# Altium schematic sheet [04] - ETHERCAT.SchDoc(ETHERCAT)
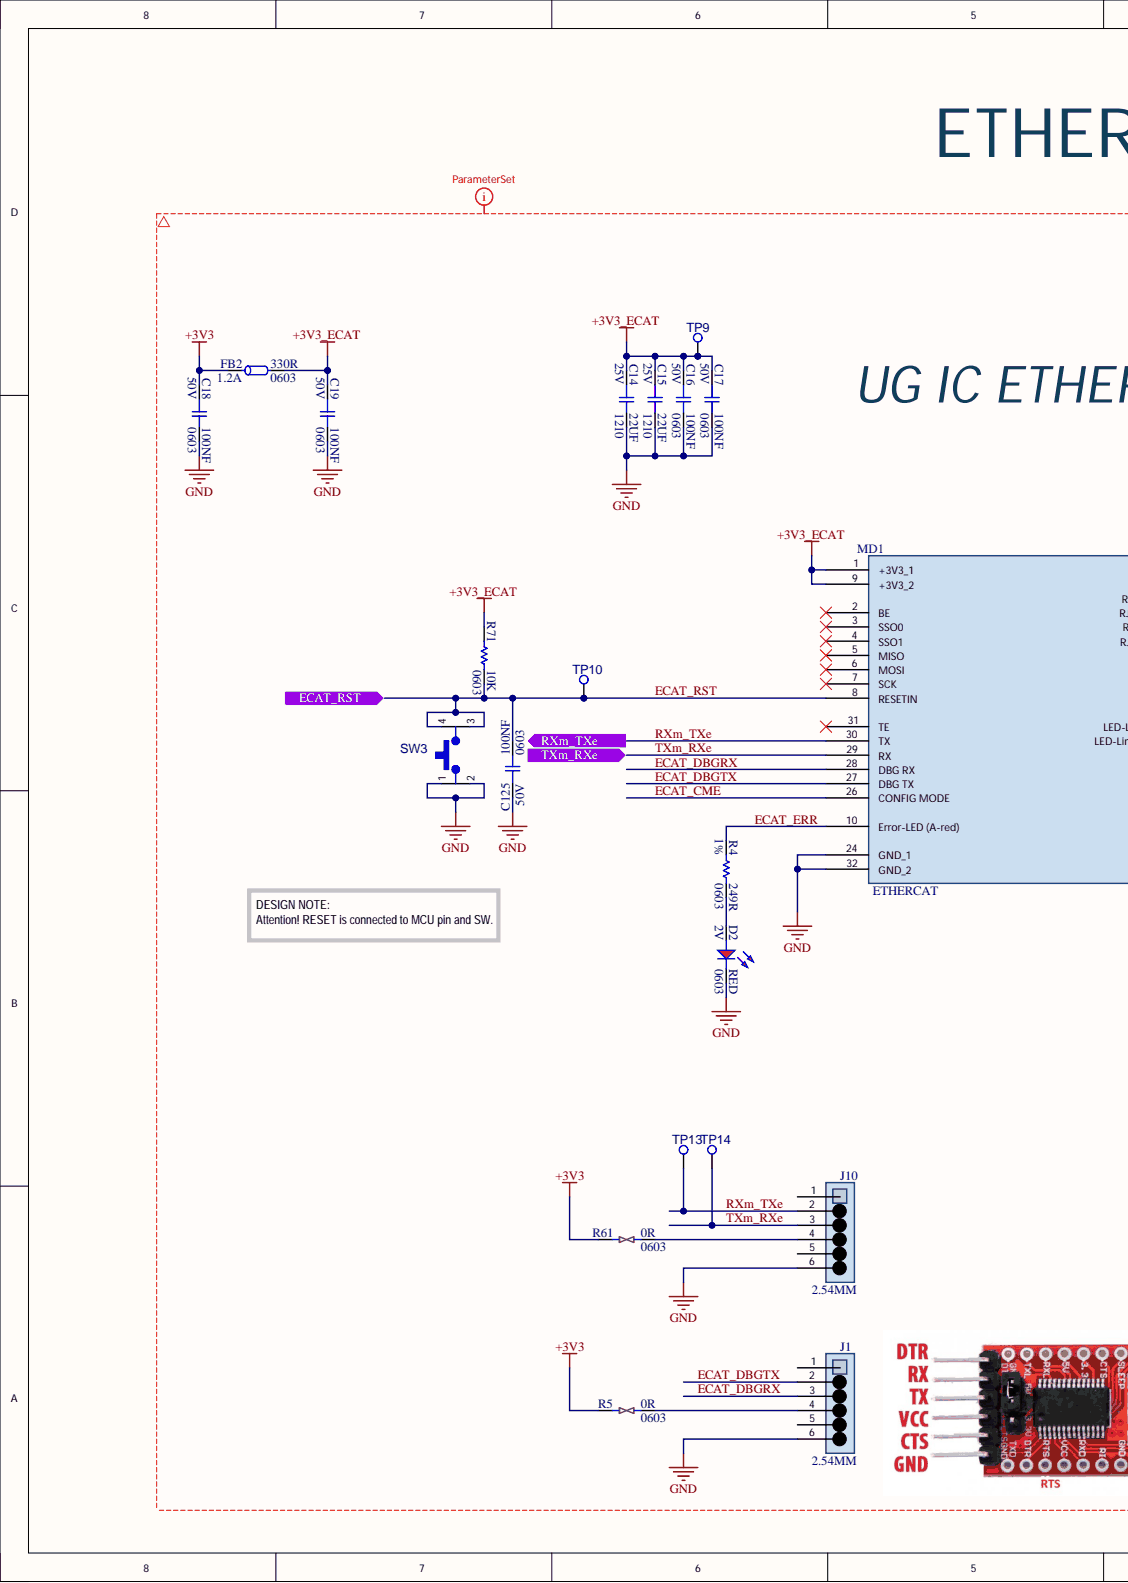
[diagram p0: [04] - ETHERCAT.SchDoc, left]
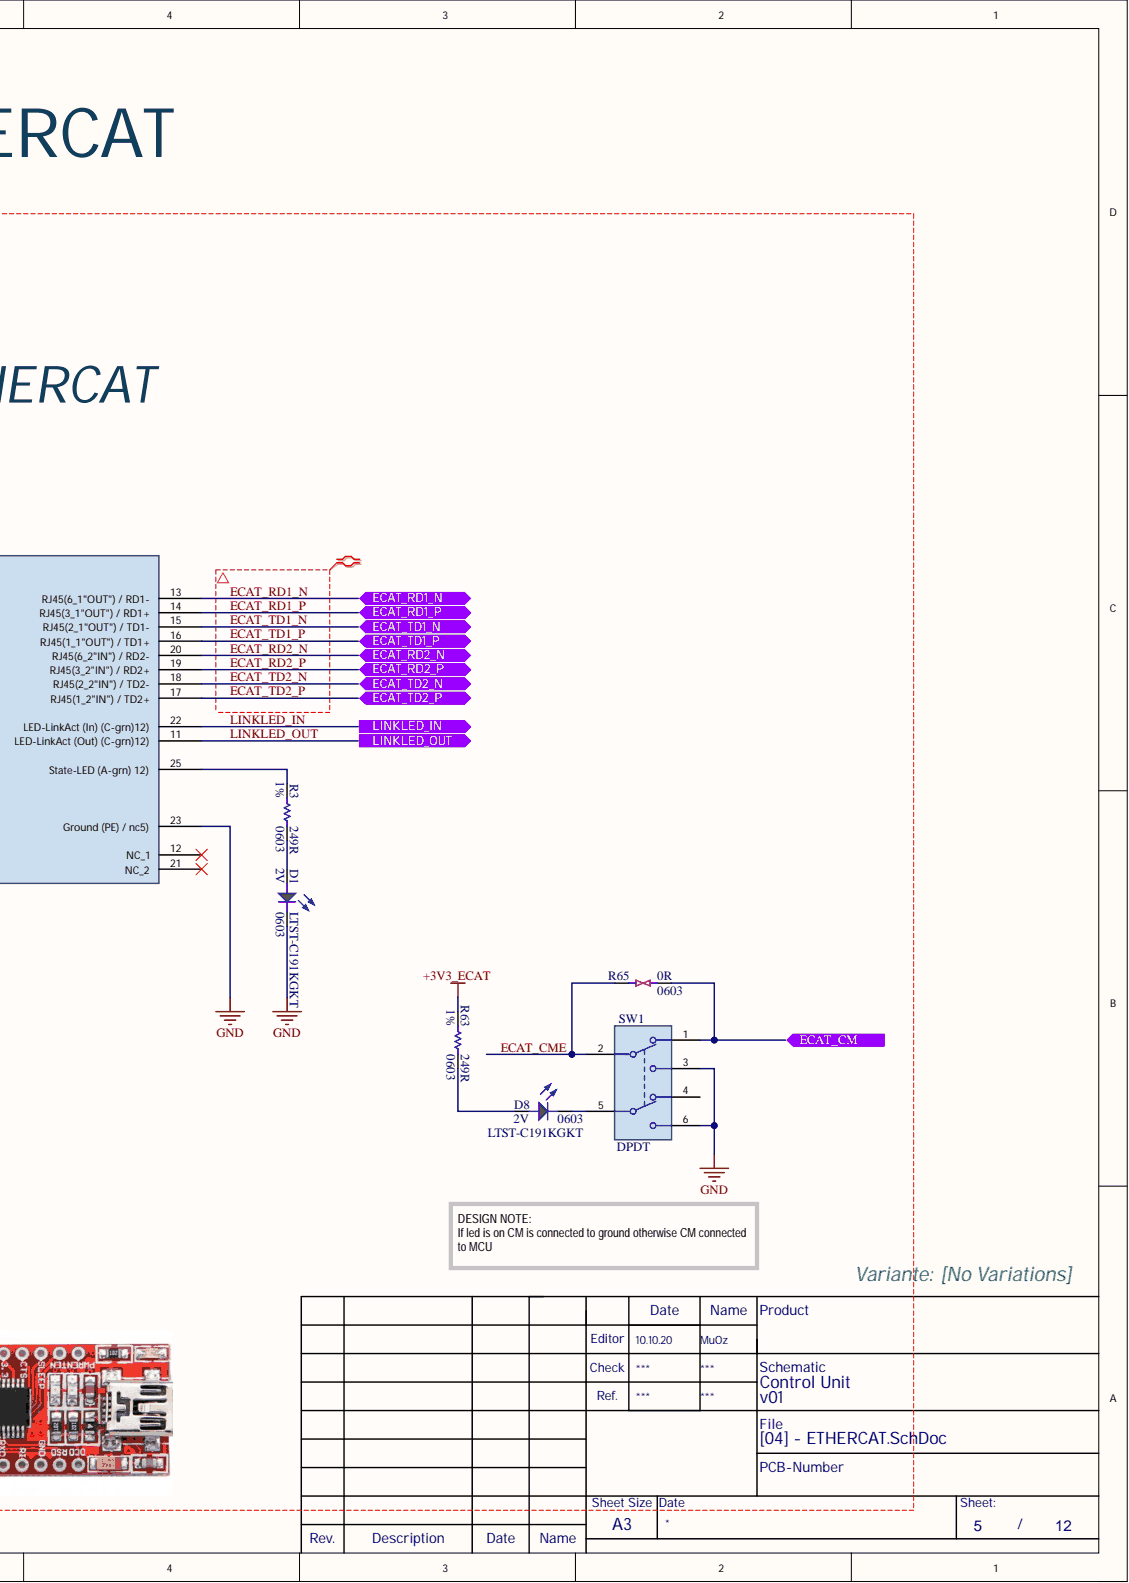
[diagram p1: [04] - ETHERCAT.SchDoc, right]

## Parts
C14  22UF  Case Code (Imperial): 1210  Voltage: 25V  [C-1210]
C15  22UF  Case Code (Imperial): 1210  Voltage: 25V  [C-1210]
C16  100NF  Case Code (Imperial): 0603  Voltage: 50V  [C-0603]
C17  100NF  Case Code (Imperial): 0603  Voltage: 50V  [C-0603]
C18  100NF  Case Code (Imperial): 0603  Voltage: 50V  [C-0603]
C19  100NF  Case Code (Imperial): 0603  Voltage: 50V  [C-0603]
C125  100NF  Case Code (Imperial): 0603  Voltage: 50V  [C-0603]
D1  LTST-C191KGKT  Case Code (Imperial): 0603  Forward Voltage: 2V  [LED-0603-GREEN]
D2  RED  Case Code (Imperial): 0603  Forward Voltage: 2V  [LED-0603]
D8  LTST-C191KGKT  Case Code (Imperial): 0603  Forward Voltage: 2V  [LED-0603-GREEN]
FB2  330R  Case Code (Imperial): 0603  Current Rating: 1.2A  [FB-0603]
J1  2.54MM  [CON-1X6-VERT-2.54MM]
J10  2.54MM  [CON-1X6-VERT-2.54MM]
MD1  ETHERCAT  [MD-UG-IC-PIN]
R3  249R  Case Code (Imperial): 0603  Tolerance: 1%  [R-0603]
R4  249R  Case Code (Imperial): 0603  Tolerance: 1%  [R-0603]
R5  0R  Case Code (Imperial): 0603  [JMP-0603]
R61  0R  Case Code (Imperial): 0603  [JMP-0603]
R63  249R  Case Code (Imperial): 0603  Tolerance: 1%  [R-0603]
R65  0R  Case Code (Imperial): 0603  [JMP-0603]
R71  10K  Case Code (Imperial): 0603  [R-0603]
SW1  DPDT  [SW-AYZ0202AGRLC]
SW3  SW-TACTILE-5MM  [TACTILE_SMD_V2]  (value not drawn)
TP9  MECH-TESTPOINT  [MECH-TESTPOINT]  (value not drawn)  (no BOM)
TP10  MECH-TESTPOINT  [MECH-TESTPOINT]  (value not drawn)  (no BOM)
TP13  MECH-TESTPOINT  [MECH-TESTPOINT]  (value not drawn)  (no BOM)
TP14  MECH-TESTPOINT  [MECH-TESTPOINT]  (value not drawn)  (no BOM)

## Ports
ECAT_CM, ECAT_RD1_N, ECAT_RD1_P, ECAT_RD2_N, ECAT_RD2_P, ECAT_RST, ECAT_TD1_N, ECAT_TD1_P, ECAT_TD2_N, ECAT_TD2_P, LINKLED_IN, LINKLED_OUT, RXm_TXe, TXm_RXe

## Nets
+3V3: C18.1 FB2.1 R5.1 R61.1
+3V3_ECAT: C14.1 C15.1 C16.1 C17.1 C19.1 FB2.2 MD1.1(+3V3_1) MD1.9(+3V3_2) R63.1 R71.1 TP9.1
GND: C14.2 C15.2 C16.2 C17.2 C18.2 C19.2 C125.1 D1.C D2.C J1.6 J10.6 MD1.23(Ground_(PE)_/_nc5)) MD1.24(GND_1) MD1.32(GND_2) SW1.3 SW1.6 SW3.1 SW3.2
ECAT_CM: R65.2 SW1.1 ; port ECAT_CM
ECAT_CME: MD1.26(CONFIG_MODE) R65.1 SW1.2
ECAT_DBGRX: J1.3 MD1.28(DBG_RX)
ECAT_DBGTX: J1.2 MD1.27(DBG_TX)
ECAT_ERR: MD1.10(Error-LED_(A-red)) R4.1
ECAT_RD1_N: MD1.13(RJ45(6_1"OUT")_/_RD1-) ; port ECAT_RD1_N
ECAT_RD1_P: MD1.14(RJ45(3_1"OUT")_/_RD1+) ; port ECAT_RD1_P
ECAT_RD2_N: MD1.20(RJ45(6_2"IN")_/_RD2-) ; port ECAT_RD2_N
ECAT_RD2_P: MD1.19(RJ45(3_2"IN")_/_RD2+) ; port ECAT_RD2_P
ECAT_RST: C125.2 MD1.8(RESETIN) R71.2 SW3.3 SW3.4 TP10.1 ; port ECAT_RST
ECAT_TD1_N: MD1.15(RJ45(2_1"OUT")_/_TD1-) ; port ECAT_TD1_N
ECAT_TD1_P: MD1.16(RJ45(1_1"OUT")_/_TD1+) ; port ECAT_TD1_P
ECAT_TD2_N: MD1.18(RJ45(2_2"IN")_/_TD2-) ; port ECAT_TD2_N
ECAT_TD2_P: MD1.17(RJ45(1_2"IN")_/_TD2+) ; port ECAT_TD2_P
LINKLED_IN: MD1.22(LED-LinkAct_(In)_(C-grn)12)) ; port LINKLED_IN
LINKLED_OUT: MD1.11(LED-LinkAct_(Out)_(C-grn)12)) ; port LINKLED_OUT
RXm_TXe: J10.2 MD1.30(TX) TP13.1 ; port RXm_TXe
TXm_RXe: J10.3 MD1.29(RX) TP14.1 ; port TXm_RXe
NetD1_A: D1.A R3.2
NetD2_A: D2.A R4.2
NetD8_A: D8.A R63.2
NetD8_C: D8.C SW1.5
NetJ1_4: J1.4 R5.2
NetJ10_4: J10.4 R61.2
NetMD1_25: MD1.25(State-LED_(A-grn)_12)) R3.1

Not connected: J1 1 5; J10 1 5; MD1 2(BE) 3(SSO0) 4(SSO1) 5(MISO) 6(MOSI) 7(SCK) 12(NC_1) 21(NC_2) 31(TE); SW1 4

## Library data of the parts
C14 C15 = C-22UF-1210: CAP CER 22UF 25V X7R 1210; Manufacturer: Samsung; Manufacturer Part Number: CL32B226KAJNNNE; .Creator: F:TALI; .PCBCHK: CHECKED; Dielectric Material: Ceramic; Dissipation Factor: 10%; Washability: OK; .SCHCHK: CHECKED; Capacitance: 22uF; Case Code (Metric): 3225; Case/Package: 1210; Component Kind: Standard; ComponentLink1Description: https://media.digikey.com/pdf/Data%20Sheets/Samsung%20PDFs/CL_Series_MLCC_ds.pdf; ComponentLink1URL: https://media.digikey.com/pdf/Data%20Sheets/Samsung%20PDFs/CL_Series_MLCC_ds.pdf; ComponentLink2Description: http://www.samsungsem.com/kr/support/product-search/mlcc/CL32B226KAJNFNE.jsp; ComponentLink2URL: http://www.samsungsem.com/kr/support/product-search/mlcc/CL32B226KAJNFNE.jsp; Depth: 2.5mm; Dielectric: X7R; Height: 2.5mm; Lead Free: Lead Free; Length: 3.2mm; Material: Ceramic; Max Operating Temperature: 125°C; Min Operating Temperature: -55°C; Mount: Surface Mount; Package Quantity: 1; Packaging: Tape and Reel; Pricing 1: 1=1.02, 10=0.777, 100=0.556, 500=0.43042 (USD); Pricing 2: 1=0.91, 10=0.671, 100=0.4598, 500=0.36402 (USD); Pricing 3: 1=1.63, 10=1.289, 100=0.9664, 500=0.7302 (USD); Radiation Hardening: No; Stock 1: 0; Stock 2: 0; Stock 3: 0; Supplier 1: Digi-Key; Supplier 2: Digi-Key; Supplier 3: Digi-Key; Supplier Part Number 1: 1276-3392-1-ND; Supplier Part Number 2: 1276-3391-6-ND; Supplier Part Number 3: 399-11958-6-ND; Termination: SMD/SMT; Thickness: 0.106inch; Tolerance: 10%; Voltage Rating: 25V; Voltage Rating (DC): 25V; Width: 0.098inch; Library Name: BARKO_SCHLIB.SchLib
C16-C19 C125 = C-100NF-0603: CAP CER 0.1UF 50V X7R 0603; Manufacturer: TDK; Manufacturer Part Number: C1608X7R1H104K080AA; .Creator: F:TALI; .PCBCHK: USED; Dielectric Material: Ceramic; Temperature Coefficient: 30ppm/°C; Tolerance: 10%; Washability: OK; .SCHCHK: USED; Capacitance: 100nF; Case Code (Metric): 1608; Case/Package: 0603; Component Kind: Standard; ComponentLink1Description: http://www.mouser.com/ds/2/400/lcc_commercial_general_en-837201.pdf; ComponentLink1URL: http://www.mouser.com/ds/2/400/lcc_commercial_general_en-837201.pdf; ComponentLink2Description: https://product.tdk.com/info/en/catalog/spec/mlccspec_commercial_general_midvoltage_en.pdf; ComponentLink2URL: https://product.tdk.com/info/en/catalog/spec/mlccspec_commercial_general_midvoltage_en.pdf; ComponentLink3Description: http://uk.rs-online.com/webdocs/13d1/0900766b813d1103.pdf; ComponentLink3URL: http://uk.rs-online.com/webdocs/13d1/0900766b813d1103.pdf; ComponentLink4Description: http://www.tdk.co.jp/tefe02/e412_c.pdf; ComponentLink4URL: http://www.tdk.co.jp/tefe02/e412_c.pdf; ComponentLink5Description: http://www.farnell.com/datasheets/2291921.pdf; ComponentLink5URL: http://www.farnell.com/datasheets/2291921.pdf; ComponentLink6Description: http://www.farnell.com/datasheets/1744655.pdf; ComponentLink6URL: http://www.farnell.com/datasheets/1744655.pdf; ComponentLink7Description: http://catalogs.avx.com/SurfaceMount.pdf; ComponentLink7URL: http://catalogs.avx.com/SurfaceMount.pdf; ComponentLink8Description: http://www.farnell.com/datasheets/630895.pdf; ComponentLink8URL: http://www.farnell.com/datasheets/630895.pdf; ComponentLink9Description: http://www.avx.com/docs/Catalogs/cx7r.pdf; ComponentLink9URL: http://www.avx.com/docs/Catalogs/cx7r.pdf; ComponentLink10Description: http://www.avx.com/docs/catalogs/cauto.pdf; ComponentLink10URL: http://www.avx.com/docs/catalogs/cauto.pdf; ComponentLink11Description: https://www.verical.com/server-webapp/html/getDatasheet?partID=98691; ComponentLink11URL: https://www.verical.com/server-webapp/html/getDatasheet?partID=98691; ComponentLink12Description: https://www.avx.com/docs/Catalogs/flexiterm.pdf; ComponentLink12URL: https://www.avx.com/docs/Catalogs/flexiterm.pdf; Composition: Ceramic; Contact Plating: Matte,Tin; Depth: 0.8mm; Dielectric: X7R; Features: Soft Termination; Height: 0.8mm; Lead Free: Lead Free; Length: 1.6mm; Max Operating Temperature: 125°C; Min Operating Temperature: -55°C; Mount: Surface Mount; Number of Pins: 2; Package Quantity: 1; Packaging: Tape and Reel; Pricing 1: 1=0.1, 10=0.057, 100=0.0254, 500=0.01812, 1000=0.01423 (USD); Pricing 2: 1=0.23, 10=0.161, 100=0.0756, 500=0.05304, 1000=0.0439 (USD); Radiation Hardening: No; REACH SVHC: No SVHC; Stock 1: 0; Stock 2: 40000; Supplier 1: Digi-Key; Supplier 2: Digi-Key; Supplier Part Number 1: 445-1314-1-ND; Supplier Part Number 2: 478-3540-6-ND; Termination: SMD/SMT; Thickness: 0.037inch; Voltage Rating: 50V; Voltage Rating (DC): 50V; Weight: 7.1E-05oz; Width: 0.8mm; Library Name: BARKO_SCHLIB.SchLib
D1 D8 = LED-GREEN-0603: LED GREEN CLEAR 0603 SMD; Manufacturer: Vishay Lite-On; .Creator: F:TALI; .PCBCHK: USED; Dominant Wavelength: 571nm; Forward Current: 30mA; Lens Colour: Clear,Colorless; Lens Style: Clear,Colorless,Diffused,Rectangular; Luminous Intensity: 35mcd; Number of Elements: 1; Power Dissipation: 75mW; Reverse Voltage: 5V; Test Current: 20mA; Viewing Angle: 130°; Washability: OK; Wavelength: 574nm; .SCHCHK: USED; Case Code (Metric): 1608; Case/Package: 0603; Colour: Green; Component Kind: Standard; ComponentLink1Description: http://www.mouser.com/ds/2/239/LTST-C191KGKT-1141954.pdf; ComponentLink1URL: http://www.mouser.com/ds/2/239/LTST-C191KGKT-1141954.pdf; ComponentLink2Description: http://optoelectronics.liteon.com/upload/download/DS22-2000-228/LTST-C191KGKT.PDF; ComponentLink2URL: http://optoelectronics.liteon.com/upload/download/DS22-2000-228/LTST-C191KGKT.PDF; ComponentLink3Description: https://www.verical.com/server-webapp/html/getDatasheet?partID=103913; ComponentLink3URL: https://www.verical.com/server-webapp/html/getDatasheet?partID=103913; ComponentLink4Description: http://uk.rs-online.com/webdocs/0dc0/0900766b80dc02b1.pdf; ComponentLink4URL: http://uk.rs-online.com/webdocs/0dc0/0900766b80dc02b1.pdf; ComponentLink5Description: http://www1.futureelectronics.com/doc/LITE-ON/LTST-C191KGKT.pdf; ComponentLink5URL: http://www1.futureelectronics.com/doc/LITE-ON/LTST-C191KGKT.pdf; Depth: 0.8mm; Height: 0.55mm; Illumination Colour: Green; Lead Free: Lead Free; Length: 1.6mm; Lens Transparency: Clear; Manufacturer Part Number: LTST-C191KGKT; Max Operating Temperature: 85°C; Min Operating Temperature: -55°C; Mount: Surface Mount; Number of LEDs: 1; Number of Pins: 2; Package Quantity: 1; Packaging: Tape and Reel; Power Rating: 75mW; Pricing 1: 1=0.29, 10=0.215, 25=0.16, 100=0.1153, 250=0.0798, 500=0.07088, 1000=0.05644, 2500=0.05533 (USD); Radiation Hardening: No; Stock 1: 2123523; Supplier 1: Digi-Key; Supplier Part Number 1: 160-1446-1-ND; Width: 0.8mm; Library Name: BARKO_SCHLIB.SchLib
D2 = LED-RED-0603: LED RED CLEAR 0603 SMD; Manufacturer: Wurth Electronics; Manufacturer Part Number: 150060RS75000; Dominant Wavelength: 625nm; Forward Current: 30mA; Lens Style: Clear,Rectangular; Luminous Intensity: 250mcd; Test Current: 20mA; Viewing Angle: 140°; Case Code (Metric): 1608; Case/Package: 0603; Color: Red; Component Kind: Standard; ComponentLink1Description: https://datasheet.ciiva.com/29563/150060rs75000-29563040.pdf?src-supplier=Digi-Key; ComponentLink1URL: https://datasheet.ciiva.com/29563/150060rs75000-29563040.pdf?src-supplier=Digi-Key; ComponentLink2Description: https://datasheet.ciiva.com/29279/759428-da-01-en-chipled-0603-w-kl-rot-150060rs75000-29279196.pdf?src-supplier=Conrad; ComponentLink2URL: https://datasheet.ciiva.com/29279/759428-da-01-en-chipled-0603-w-kl-rot-150060rs75000-29279196.pdf?src-supplier=Conrad; Height: 0.7mm; Length: 1.6mm; Max Operating Temperature: 85°C; Min Operating Temperature: -40°C; Mount: Surface Mount; Package Quantity: 1; Packaging: Cut Tape; Pricing: 1=0.14, 50=0.133, 100=0.114, 500=0.099 (USD); REACH SVHC: Unknown; RoHS: Yes; Stock: 110086; Supplier 1: Digi-Key; Supplier Part Number 1: 732-4978-1-ND; Wavelength: 625nm; Width: 0.8mm; Library Name: BARKO_SCHLIB.SchLib
FB2 = FB-330R-0603: FERRITE BEAD 330 OHM 0603 1LN; Manufacturer: Murata; Manufacturer Part Number: BLM18PG331SN1D; .Creator: M.OZKAN; .PCBCHK: USED; DC Resistance (DCR): 150mR; Material: Steel,Ferrite; Number of Circuits: 1; Shielding: Unshielded; Test Frequency: 100MHz; Tolerance: 25%; Washability: OK; .SCHCHK: USED; Case Code (Metric): 1608; Case/Package: 0603; Component Kind: Standard; ComponentLink1Description: https://datasheet.ciiva.com/6211/enfa0003-6211710.pdf?src-supplier=Digi-Key; ComponentLink1URL: https://datasheet.ciiva.com/6211/enfa0003-6211710.pdf?src-supplier=Digi-Key; Core Material: Ferrite; DC Current: 1.2A; Depth: 0.95mm; Height: 0.8mm; Impedance: 330R; Lead Free: Lead Free; Length: 1.75mm; Max Current Rating: 1.2A; Max DC Current: 1.2A; Max Operating Temperature: 125°C; Min Operating Temperature: -55°C; Number of Lines: 1; Number of Pins: 2; Package Quantity: 1; Packaging: Tape and Reel; Pricing: 1=0.1, 10=0.076, 25=0.0684, 50=0.05, 100=0.0433, 250=0.0342, 500=0.0288, 1000=0.0243 (USD); Radiation Hardening: No; REACH SVHC: No SVHC; RoHS: Yes; Stock: 106218; Supplier 1: Digi-Key; Supplier Part Number 1: 490-5222-1-ND; Termination: SMD/SMT; Width: 0.8mm; Library Name: BARKO_SCHLIB.SchLib
J1 J10 = CON-6POS-2.54MM: CONN RCPT 6POS 0.1 TIN PCB; Manufacturer 1: Harwin; Manufacturer Part Number: M20-7820646; Connector Type: Receptacle,Socket; Contact Resistance: 20mR; Gender: Female; Max Current Rating: 3A; Number of Contacts: 6; Case/Package: SIL; Category: Headers and Wire Housings; Color: Black; Component Kind: Standard; ComponentLink1Description: http://components.arrow.com/part/dataview/0323_00725884?src-supplier=Arrow+Europe; ComponentLink1URL: http://components.arrow.com/part/dataview/0323_00725884?src-supplier=Arrow+Europe; ComponentLink2Description: http://www.harwin.com/include/downloads/pdfs/2010PAGE120.PDF?src-supplier=Avnet; ComponentLink2URL: http://www.harwin.com/include/downloads/pdfs/2010PAGE120.PDF?src-supplier=Avnet; ComponentLink3Description: https://cdn.harwin.com/pdfs/M20-782.pdf?src-supplier=Digi-Key; ComponentLink3URL: https://cdn.harwin.com/pdfs/M20-782.pdf?src-supplier=Digi-Key; ComponentLink4Description: https://cdn.harwin.com/pdfs/Harwin_PC_Connectors_M20.pdf?src-supplier=Avnet+Europe; ComponentLink4URL: https://cdn.harwin.com/pdfs/Harwin_PC_Connectors_M20.pdf?src-supplier=Avnet+Europe; ComponentLink5Description: https://cdn.harwin.com/pdfs/Harwin_PC_Connectors_M20.pdf?src-supplier=RSComponents; ComponentLink5URL: https://cdn.harwin.com/pdfs/Harwin_PC_Connectors_M20.pdf?src-supplier=RSComponents; ComponentLink6Description: https://cdn.harwin.com/pdfs/Harwin_PC_Connectors_M20.pdf?src-supplier=Mouser; ComponentLink6URL: https://cdn.harwin.com/pdfs/Harwin_PC_Connectors_M20.pdf?src-supplier=Mouser; ComponentLink7Description: https://cdn.harwin.com/pdfs/Harwin_PC_Connectors_M20.pdf?src-supplier=TTI; ComponentLink7URL: https://cdn.harwin.com/pdfs/Harwin_PC_Connectors_M20.pdf?src-supplier=TTI; Contact Gender: Female; Contact Material: Bronze; Contact Plating: Tin; Depth: 2.5mm; Housing Color: Black; Housing Material: Nylon; Length: 15.64mm; Library Name: SCHLIB.SchLib; Max Operating Temperature: 105°C; Min Operating Temperature: -40°C; Mount: Through Hole; Number of Positions: 6; Number of Rows: 1; Orientation: Straight; Package Quantity: 38; Packaging: Rail/Tube; Pitch: 2.54mm; Pricing: 1=0,96000, 10=0,84200, 100=0,72600, 500=0,59400, 1000=0,52800, 5000=0,46200, 10000=0,43890 (USD); Radiation Hardening: No; RoHS: Yes; Stock: 1847; Supplier 1: Digi-Key; Supplier Part Number 1: 952-1809-ND; Termination: Solder
MD1 = MD-UG IC ETHERCAT: UNIGATE® IC - EtherCAT®; Component Kind: Standard; ComponentLink1Description: Datasheet; ComponentLink1URL: https://www.deutschmann.de/en/products/embedded-solutions/unigate-ic/unigate-ic-profinet/?pdf=1&id=0&bs2=0; ComponentLink2Description: Manuel; ComponentLink2URL: https://www.deutschmann.de/downloads//Marketing/Anleitung-Instructions/Handbuecher-Manuals/Gateway/English/UNIGATE%20IC/IC%20PROFINET/IC_PN2Port/IC_PN2Port_e.pdf; Library Name: SCHLIB.SchLib
R3 R4 R63 = R-249R-0603: RES 249 OHM 1% 1/10W 0603; Manufacturer: Stackpole Electronics; Manufacturer Part Number: RMCF0603FT249R; Max Power Dissipation: 100mW; Temperature Coefficient: 100ppm/°C; Case Code (Metric): 1608; Case/Package: 0603; Component Kind: Standard; ComponentLink1Description: http://components.arrow.com/part/dataview/50577616S9712727N1174?src-supplier=Arrow+Electronics; ComponentLink1URL: http://components.arrow.com/part/dataview/50577616S9712727N1174?src-supplier=Arrow+Electronics; ComponentLink2Description: https://www.seielect.com/catalog/SEI-RMCF_RMCP.pdf?src-supplier=Avnet; ComponentLink2URL: https://www.seielect.com/catalog/SEI-RMCF_RMCP.pdf?src-supplier=Avnet; ComponentLink3Description: https://www.seielect.com/Catalog/SEI-RMCF_RMCP.pdf?src-supplier=Digi-Key; ComponentLink3URL: https://www.seielect.com/Catalog/SEI-RMCF_RMCP.pdf?src-supplier=Digi-Key; ComponentLink4Description: https://www.seielect.com/catalog/SEI-Packaging.pdf?src-supplier=Digi-Key; ComponentLink4URL: https://www.seielect.com/catalog/SEI-Packaging.pdf?src-supplier=Digi-Key; ComponentLink5Description: http://www.seielect.com/Catalog/SEI-RMC.pdf?src-supplier=Future+Electronics; ComponentLink5URL: http://www.seielect.com/Catalog/SEI-RMC.pdf?src-supplier=Future+Electronics; Composition: Thick Film; Contact Plating: Tin,Matte; Features: Automotive AEC-Q200; Height: 0.022inch; Lead Free: Lead Free; Length: 0.061inch; Max Operating Temperature: 155°C; Min Operating Temperature: -55°C; Mount: Surface Mount; Number of Terminations: 2; Packaging: Tape and Reel; Power Rating: 100mW; Pricing: 1=0.1, 10=0.015, 100=0.0063, 1000=0.0028, 2500=0.0024 (USD); Radiation Hardening: No; Resistance: 249R; RoHS: Yes; Stock: 137849; Supplier 1: Digi-Key; Supplier Part Number 1: RMCF0603FT249RCT-ND; Width: 0.031inch; Library Name: BARKO_SCHLIB.SchLib
R5 R61 R65 = R-0R-0603: RES SMD 0 OHM 1/10W JUMP 0603; Manufacturer: Yageo; Manufacturer Part Number: RC0603JR-070RL; .Creator: F:TALI; .PCBCHK: USED; Current Rating: 1A; Max Power Dissipation: 100mW; Resistance: 0mR; Temperature Coefficient: 200ppm/°C; Tolerance: 1%; Voltage Rating: 50V; Washability: OK; Working Voltage: 75V; .SCHCHK: USED; Case Code (Metric): 1608; Case/Package: 0603; Component Kind: Standard; ComponentLink1Description: http://www.farnell.com/datasheets/1738695.pdf; ComponentLink1URL: http://www.farnell.com/datasheets/1738695.pdf; ComponentLink2Description: https://www.verical.com/server-webapp/html/getDatasheet?partID=329462; ComponentLink2URL: https://www.verical.com/server-webapp/html/getDatasheet?partID=329462; ComponentLink3Description: http://www.yageo.com/documents/recent/PYu-RC_Group_51_RoHS_L_9.pdf; ComponentLink3URL: http://www.yageo.com/documents/recent/PYu-RC_Group_51_RoHS_L_9.pdf; ComponentLink4Description: http://www.farnell.com/datasheets/443971.pdf; ComponentLink4URL: http://www.farnell.com/datasheets/443971.pdf; ComponentLink5Description: http://documents.rs-components.com/techlib://itc_doclist_GB.htm?stockno=6515918; ComponentLink5URL: http://documents.rs-components.com/techlib://itc_doclist_GB.htm?stockno=6515918; ComponentLink6Description: http://www.farnell.com/datasheets/1868787.pdf; ComponentLink6URL: http://www.farnell.com/datasheets/1868787.pdf; ComponentLink7Description: http://documents.rs-components.com/techlib://itc_doclist_GB.htm?stockno=5048827; ComponentLink7URL: http://documents.rs-components.com/techlib://itc_doclist_GB.htm?stockno=5048827; ComponentLink8Description: http://industrial.panasonic.com/www-data/pdf/AOA0000/AOA0000CE1.pdf; ComponentLink8URL: http://industrial.panasonic.com/www-data/pdf/AOA0000/AOA0000CE1.pdf; ComponentLink9Description: http://www.farnell.com/datasheets/2059623.pdf; ComponentLink9URL: http://www.farnell.com/datasheets/2059623.pdf; ComponentLink10Description: http://www.jameco.com/Jameco/Products/ProdDS/1935748.pdf; ComponentLink10URL: http://www.jameco.com/Jameco/Products/ProdDS/1935748.pdf; ComponentLink11Description: http://www.farnell.com/datasheets/1678796.pdf; ComponentLink11URL: http://www.farnell.com/datasheets/1678796.pdf; ComponentLink12Description: http://www.alliedelec.com/m/d/51cd0790071e1666bdb9d00c57fcf960.pdf; ComponentLink12URL: http://www.alliedelec.com/m/d/51cd0790071e1666bdb9d00c57fcf960.pdf; Composition: Thick Film; Contact Plating: Matte,Tin; Depth: 0.8mm; Features: Moisture Resistant; Height: 0.45mm; Lead Free: Lead Free; Length: 1.6mm; Max Operating Temperature: 155°C; Military Standard: Not; Min Operating Temperature: -55°C; Mount: Surface Mount; Number of Pins: 2; Number of Terminations: 2; Package Quantity: 5000; Packaging: Tape and Reel; Power Rating: 100mW; Pricing 1: 1=0.1, 10=0.021, 25=0.0152, 50=0.0114, 100=0.0084, 250=0.00644, 500=0.00514, 1000=0.00379 (USD); Pricing 2: 1=0.1, 10=0.021, 25=0.0152, 50=0.0114, 100=0.0084, 250=0.00644, 500=0.00514, 1000=0.00379 (USD); Pricing 3: 1=0.1, 10=0.042, 25=0.0304, 50=0.0232, 100=0.0171, 250=0.01308, 500=0.01046, 1000=0.0077 (USD); Radiation Hardening: No; REACH SVHC: No SVHC; Stock 1: 54397731; Stock 2: 6104331; Stock 3: 34178498; Supplier 1: Digi-Key; Supplier 2: Digi-Key; Supplier 3: Digi-Key; Supplier Part Number 1: 311-0.0GRDKR-ND; Supplier Part Number 2: 311-0.0HRDKR-ND; Supplier Part Number 3: P0.0GDKR-ND; Termination: SMD/SMT; Voltage Rating (DC): 50V; Width: 0.8mm; Library Name: BARKO_SCHLIB.SchLib
R71 = R-10K-0603: RES SMD 10K OHM 1% 1/10W 0603; Manufacturer: Yageo; Manufacturer Part Number: RC0603FR-0710KL; .Creator: F:TALI; .PCBCHK: USED; Max Power Dissipation: 100mW; Resistance: 10kR; Temperature Coefficient: 100ppm/°C; Voltage Rating: 75V; Voltage Rating (DC): 50V; Washability: OK; .SCHCHK: USED; Case Code (Metric): 1608; Case/Package: 0603; Component Kind: Standard; ComponentLink1Description: http://www.farnell.com/datasheets/38590.pdf; ComponentLink1URL: http://www.farnell.com/datasheets/38590.pdf; ComponentLink2Description: https://www.verical.com/server-webapp/html/getDatasheet?partID=409289; ComponentLink2URL: https://www.verical.com/server-webapp/html/getDatasheet?partID=409289; ComponentLink3Description: http://www.farnell.com/datasheets/1868787.pdf; ComponentLink3URL: http://www.farnell.com/datasheets/1868787.pdf; ComponentLink4Description: http://www.farnell.com/datasheets/443971.pdf; ComponentLink4URL: http://www.farnell.com/datasheets/443971.pdf; ComponentLink5Description: http://www.farnell.com/datasheets/1738695.pdf; ComponentLink5URL: http://www.farnell.com/datasheets/1738695.pdf; ComponentLink6Description: http://www.yageo.com/documents/recent/PYu-RC_Group_51_RoHS_L_9.pdf; ComponentLink6URL: http://www.yageo.com/documents/recent/PYu-RC_Group_51_RoHS_L_9.pdf; ComponentLink7Description: https://www.verical.com/server-webapp/html/getDatasheet?partID=327958; ComponentLink7URL: https://www.verical.com/server-webapp/html/getDatasheet?partID=327958; ComponentLink8Description: http://www.yageo.com/documents/recent/PYu-RC_Group_51_RoHS_L_2.pdf; ComponentLink8URL: http://www.yageo.com/documents/recent/PYu-RC_Group_51_RoHS_L_2.pdf; ComponentLink9Description: http://www.farnell.com/datasheets/1678796.pdf; ComponentLink9URL: http://www.farnell.com/datasheets/1678796.pdf; ComponentLink10Description: http://industrial.panasonic.com/www-cgi/jvcr13pz.cgi?E+PZ+3+AOA0002+ERJ1GNJ120C+7+WW; ComponentLink10URL: http://industrial.panasonic.com/www-cgi/jvcr13pz.cgi?E+PZ+3+AOA0002+ERJ1GNJ120C+7+WW; ComponentLink11Description: http://industrial.panasonic.com/www-data/pdf/AOA0000/AOA0000CE1.pdf; ComponentLink11URL: http://industrial.panasonic.com/www-data/pdf/AOA0000/AOA0000CE1.pdf; ComponentLink12Description: http://www.jameco.com/Jameco/Products/ProdDS/2007265.pdf; ComponentLink12URL: http://www.jameco.com/Jameco/Products/ProdDS/2007265.pdf; ComponentLink13Description: https://industrial.panasonic.com/cdbs/www-data/pdf/RDA0000/AOA0000C301.pdf; ComponentLink13URL: https://industrial.panasonic.com/cdbs/www-data/pdf/RDA0000/AOA0000C301.pdf; Composition: Thick Film; Contact Plating: Matte,Tin; Depth: 0.8mm; Features: Automotive AEC-Q200; Height: 0.45mm; Lead Free: Lead Free; Lead Pitch: 0.3mm; Length: 1.6mm; Max Operating Temperature: 155°C; Military Standard: Not; Min Operating Temperature: -55°C; Mount: Surface Mount; Number of Pins: 2; Number of Terminations: 2; Package Quantity: 1; Packaging: Tape and Reel; Power Rating: 100mW; Pricing 1: 1=0.1, 10=0.026, 100=0.0107, 1000=0.00478, 2500=0.00415 (USD); Pricing 2: 1=0.1, 10=0.022, 100=0.0088, 1000=0.00396, 2500=0.00344 (USD); Pricing 3: 1=0.1, 10=0.044, 100=0.0178, 1000=0.00798, 2500=0.00693 (USD); Radiation Hardening: No; REACH SVHC: No SVHC; Stock 1: 37479107; Stock 2: 34952003; Stock 3: 0; Supplier 1: Digi-Key; Supplier 2: Digi-Key; Supplier 3: Digi-Key; Supplier Part Number 1: 311-10.0KHRCT-ND; Supplier Part Number 2: 311-10KGRDKR-ND; Supplier Part Number 3: YAG1290DKR-ND; Termination: SMD/SMT; Tolerance: 1%; Weight: 7.1E-05oz; Width: 0.8mm; Working Voltage: 75V; Library Name: BARKO_SCHLIB.SchLib
SW1 = SW-AYZ0202AGRLC: SWITCH SLIDE DPDT 100MA 12V; Manufacturer: ITT C&K; Actuator Length: 1mm; Actuator Material: Nylon,Glass,Polyamide,Glass fibre; Actuator Type: Standard; Contact Resistance: 80mR; Illumination Type: Non-Illuminated; Mechanical Life: 10000Cycles; Switch Function: On-On; Case/Package: 100; Component Kind: Standard; ComponentLink1Description: https://datasheet.ciiva.com/16417/ayz0202agrlc-16417120.pdf?src-supplier=Future+Electronics; ComponentLink1URL: https://datasheet.ciiva.com/16417/ayz0202agrlc-16417120.pdf?src-supplier=Future+Electronics; ComponentLink2Description: https://datasheet.ciiva.com/2889/ayz-16oct13-2889189.pdf?src-supplier=Digi-Key; ComponentLink2URL: https://datasheet.ciiva.com/2889/ayz-16oct13-2889189.pdf?src-supplier=Digi-Key; ComponentLink3Description: https://datasheet.ciiva.com/8624/ayz-16oct13-8624741.pdf?src-supplier=Avnet; ComponentLink3URL: https://datasheet.ciiva.com/8624/ayz-16oct13-8624741.pdf?src-supplier=Avnet; ComponentLink4Description: https://datasheet.ciiva.com/2889/ayz-16oct13-2889190.pdf?src-supplier=Digi-Key; ComponentLink4URL: https://datasheet.ciiva.com/2889/ayz-16oct13-2889190.pdf?src-supplier=Digi-Key; ComponentLink5Description: https://datasheet.ciiva.com/2889/ayz-16oct13-2889191.pdf?src-supplier=Digi-Key; ComponentLink5URL: https://datasheet.ciiva.com/2889/ayz-16oct13-2889191.pdf?src-supplier=Digi-Key; ComponentLink6Description: https://datasheet.ciiva.com/16174/ayz-24mar-16174248.pdf?src-supplier=Onlinecomponents.com; ComponentLink6URL: https://datasheet.ciiva.com/16174/ayz-24mar-16174248.pdf?src-supplier=Onlinecomponents.com; ComponentLink7Description: https://datasheet.ciiva.com/22630/1707705-22630555.pdf?src-supplier=Element14; ComponentLink7URL: https://datasheet.ciiva.com/22630/1707705-22630555.pdf?src-supplier=Element14; Contact Current Rating: 100mA; Contact Material: Copper Alloy,Brass,Silver; Contact Plating: Silver; Current Rating: 100mA; Depth: 6mm; Housing Material: Glass; Lead Free: Lead Free; Length: 7.2mm; Library Name: SCHLIB.SchLib; Manufacturer Part Number: AYZ0202AGRLC; Max Current Rating: 100mA; Max Operating Temperature: 60°C; Max Voltage Rating (DC): 12V; Min Operating Temperature: -10°C; Mount: Surface Mount,PCB; Orientation: Vertical; Package Quantity: 1; Packaging: Tape and Reel; Pricing: 1=1.326, 50=1.209, 100=1.105, 1000=1.027, 3000=0.962, 1=10.2, 50=9.3, 100=8.5, 1000=7.9, 3000=7.4 (EUR); Radiation Hardening: No; REACH SVHC: No SVHC; RoHS: Yes; Stock: 377; Supplier 1: RSComponents; Supplier Part Number 1: 7931504; Termination: Gull Wing; Throw Configuration: DPDT; Voltage Rating (DC): 12V
SW3 = SW-TACTILE-5MM: SWITCH TACTILE SPST-NO 0.05A 24V; Manufacturer: TE Connectivity AMP; Manufacturer Part Number: FSM4JSMA; .Creator: F:TALI; .PCBCHK: USED; Actuator Length: 0.055inch; Actuator Material: Polyamide; Actuator Type: Standard; Contact Current Rating: 50mA; Contact Resistance: 100mR; Electrical Life: 100000Cycles; Illumination Type: Illuminated,Non-Illuminated; Max Voltage Rating (DC): 12V; Operating Force: 1.57N; Switch Function: Off-Mom; Switch Travel: 0.25mm; Throw Configuration: SPST; Washability: OK; .SCHCHK: USED; Case/Package: SMA; Component Kind: Standard; ComponentLink1Description: https://www.alliedelec.com/m/d/12a87fa7d0b178a96761783f66d58fa4.pdf; ComponentLink1URL: https://www.alliedelec.com/m/d/12a87fa7d0b178a96761783f66d58fa4.pdf; ComponentLink2Description: http://www.onlinecomponents.com/productFiles/mf-AO/eng_cat_1308390_0904_e3_e10_.pdf; ComponentLink2URL: http://www.onlinecomponents.com/productFiles/mf-AO/eng_cat_1308390_0904_e3_e10_.pdf; ComponentLink3Description: https://www.te.com/commerce/DocumentDelivery/DDEController?Action=srchrtrv&DocNm=1308111-1_SWITCHES_CORE_PROGRAM_CATALOG&DocType=CS&DocLang=English; ComponentLink3URL: https://www.te.com/commerce/DocumentDelivery/DDEController?Action=srchrtrv&DocNm=1308111-1_SWITCHES_CORE_PROGRAM_CATALOG&DocType=CS&DocLang=English; Contact Material: Copper Alloy; Contact Plating: Silver; Current Rating: 50mA; Length: 6mm; Max Operating Temperature: 85°C; Mechanical Life: 100000Cycles; Min Operating Temperature: -35°C; Mount: Surface Mount; Orientation: Straight; Package Quantity: 750; Packaging: Loose Piece; Pricing 1: 1=0.23, 10=0.218, 25=0.2108, 50=0.2032, 100=0.192, 250=0.18068, 500=0.17314, 1000=0.13174, 5000=0.10916 (USD); REACH SVHC: Unknown; Stock 1: 31344; Supplier 1: Digi-Key; Supplier Part Number 1: 450-1129-ND; Termination: Gull Wing; Type: Switch; Voltage Rating: 24V; Voltage Rating (DC): 24V; Width: 6mm; Library Name: BARKO_SCHLIB.SchLib
TP9 TP10 TP13 TP14 = MECH-TESTPOINT: .Creator: F:TALI; .PCBCHK: USED; Washability: OK; .SCHCHK: USED; Library Name: BARKO_SCHLIB.SchLib
